# Altium schematic sheet MCU.SchDoc(MCU)
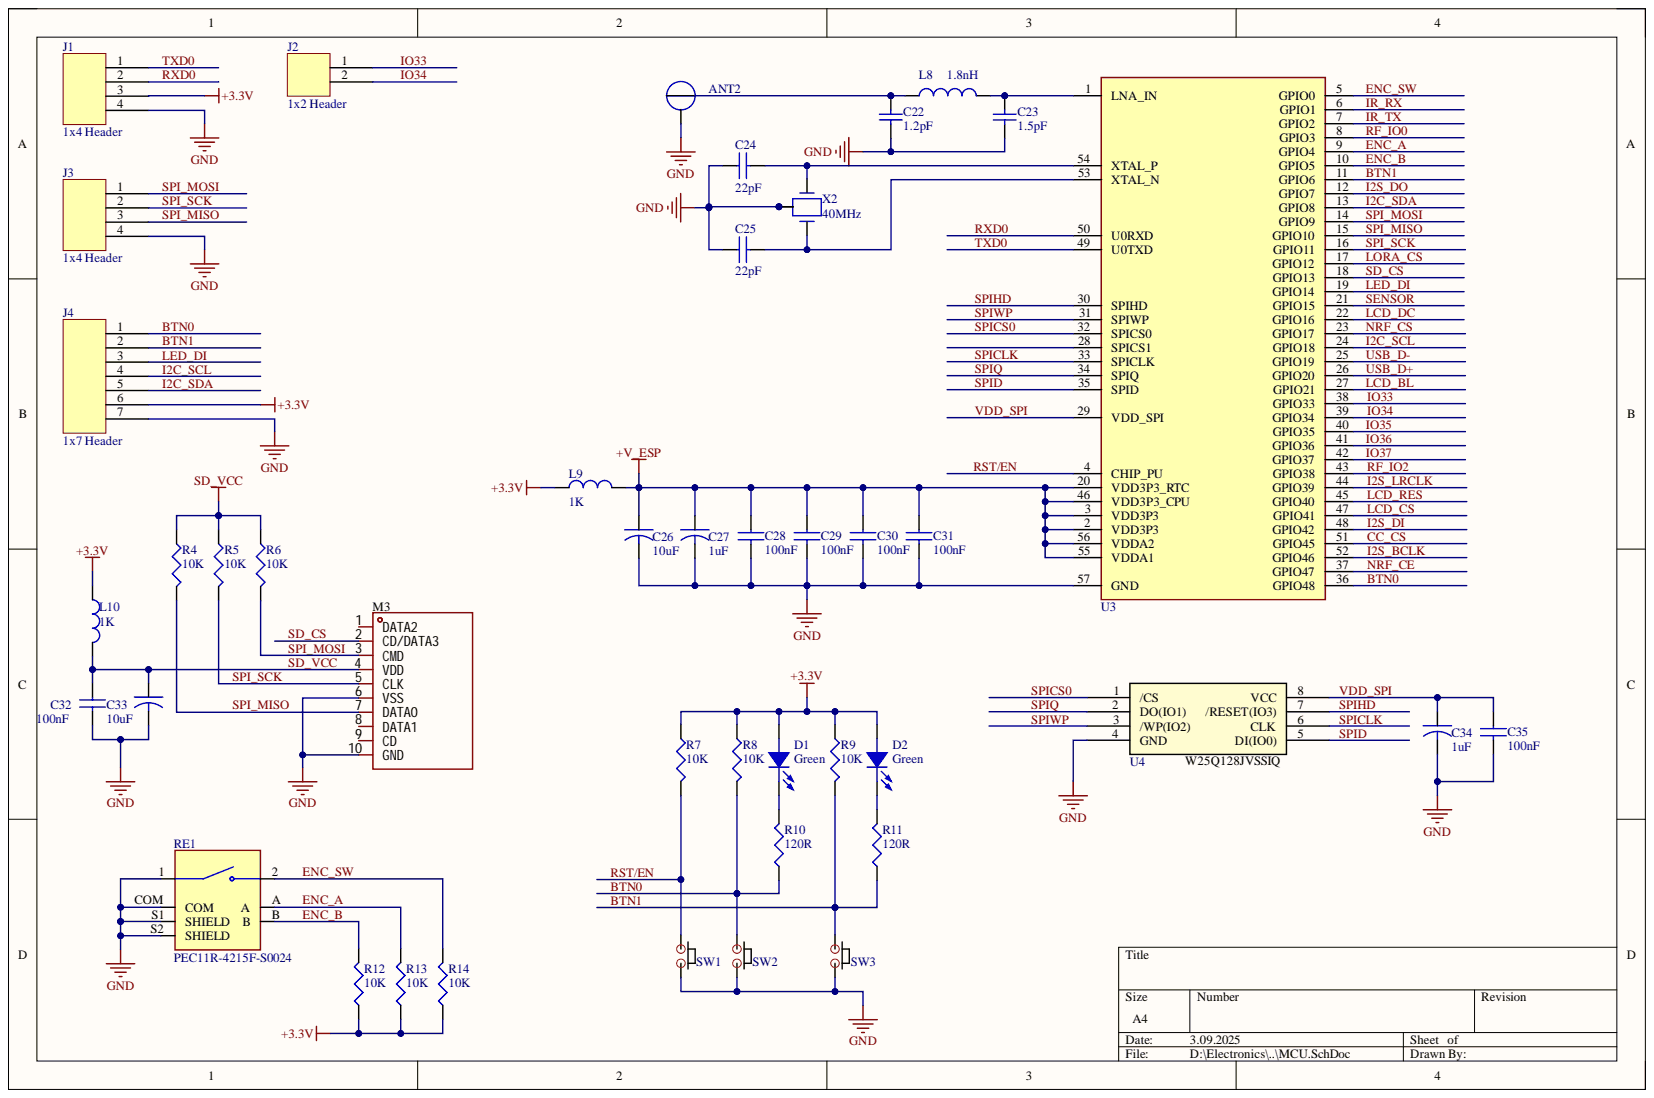
[diagram p0: MCU.SchDoc]

## Parts
ANT2  2.4HGz-PCB-ANT  [2.4HGZ-PCB-ANT]  (value not drawn)
C22  Capacitance: 1.2pF  [0603 SMD Ceramic Capacitor]  (hidden comment: CAP CER 1.2PF 25V C0G/NP0 0402)
C23  Capacitance: 1.5pF  [0603 SMD Ceramic Capacitor]  (hidden comment: CAP CER 1.5PF 25V C0G/NP0 0402)
C24  value 22pF  [0805 SMD Ceramic Capacitor]  (hidden comment: C0805C220)
C25  value 22pF  [0805 SMD Ceramic Capacitor]  (hidden comment: C0805C220)
C26  value 10uF  [A Case]  (hidden comment: T491A106)
C27  value 1uF  [A Case]  (hidden comment: T491A105)
C28  value 100nF  [0805 SMD Ceramic Capacitor]  (hidden comment: C0805C104)
C29  value 100nF  [0805 SMD Ceramic Capacitor]  (hidden comment: C0805C104)
C30  value 100nF  [0805 SMD Ceramic Capacitor]  (hidden comment: C0805C104)
C31  value 100nF  [0805 SMD Ceramic Capacitor]  (hidden comment: C0805C104)
C32  value 100nF  [0805 SMD Ceramic Capacitor]  (hidden comment: C0805C104)
C33  value 10uF  [A Case]  (hidden comment: T491A106)
C34  value 1uF  [A Case]  (hidden comment: T491A105)
C35  value 100nF  [0805 SMD Ceramic Capacitor]  (hidden comment: C0805C104)
D1  value Green  [0805 Green LED]  (hidden comment: 0805 Green LED)
D2  value Green  [0805 Green LED]  (hidden comment: 0805 Green LED)
J1  value 1x4 Header  [1x4 4 Pin Header]  (hidden comment: 1x4 4 Pin Header)
J2  value 1x2 Header  [1x2 2 Pin Header]  (hidden comment: 1x2 2 Pin Header)
J3  value 1x4 Header  [1x4 4 Pin Header]  (hidden comment: 1x4 4 Pin Header)
J4  value 1x7 Header  [1x7 7 Pin Header]  (hidden comment: 1x7 7 Pin Header)
L8  1.8nH  [0603 Inductor]
L9  value 1K  [0805 Inductor]  (hidden comment: 0805Ferrite)
L10  value 1K  [0805 Inductor]  (hidden comment: 0805Ferrite)
M3  XKTF-N02-G  [TF-SMD_XKTF-N02-G]  (value not drawn)
R4  value 10K  [0805 Resistor]  (hidden comment: 0805_10K)
R5  value 10K  [0805 Resistor]  (hidden comment: 0805_10K)
R6  value 10K  [0805 Resistor]  (hidden comment: 0805_10K)
R7  value 10K  [0805 Resistor]  (hidden comment: 0805_10K)
R8  value 10K  [0805 Resistor]  (hidden comment: 0805_10K)
R9  value 10K  [0805 Resistor]  (hidden comment: 0805_10K)
R10  value 120R  [0805 Resistor]  (hidden comment: 0805_120R)
R11  value 120R  [0805 Resistor]  (hidden comment: 0805_120R)
R12  value 10K  [0805 Resistor]  (hidden comment: 0805_10K)
R13  value 10K  [0805 Resistor]  (hidden comment: 0805_10K)
R14  value 10K  [0805 Resistor]  (hidden comment: 0805_10K)
RE1  PEC11R-4215F-S0024  [XDCR_PEC11R-4215F-S0024]
SW1  2.5mm Tactile Push Button  [2.5mm Tactile Push Button]  (value not drawn)
SW2  2.5mm Tactile Push Button  [2.5mm Tactile Push Button]  (value not drawn)
SW3  2.5mm Tactile Push Button  [2.5mm Tactile Push Button]  (value not drawn)
U3  ESP32-S3R8  [QFN40P700X700X90-57N]  (value not drawn)
U4  value W25Q128JVSSIQ  Manufacturer Code: W25Q128JVSSIQ  [SOIC-8_5P28X5P28_WIN]  (hidden comment: W25Q64JVSSIQ)
X2  value 40MHz  [TSX-3225]  (hidden comment: SMD XTAL 40MHz)

## Nets
+3.3V: D1.1 D2.1 J1.3 J4.6 L9.1 L10.1 R7.1 R8.1 R9.1 R12.1 R13.1 R14.1
+V_ESP: C26.1 C27.1 C28.1 C29.1 C30.1 C31.1 L9.2 U3.2(VDD3P3) U3.3(VDD3P3) U3.20(VDD3P3_RTC) U3.46(VDD3P3_CPU) U3.55(VDDA1) U3.56(VDDA2)
GND: ANT2.2 C22.2 C23.2 C24.1 C25.1 C26.2 C27.2 C28.2 C29.2 C30.2 C31.2 C32.2 C33.2 C34.2 C35.2 J1.4 J3.4 J4.7 M3.6(VSS) M3.10(GND) RE1.1 RE1.COM RE1.S1(SHIELD) RE1.S2(SHIELD) SW1.2 SW2.2 SW3.2 U3.57(GND) U4.4(GND) X2.2 X2.4
BTN0: J4.1 R8.2 R10.1 SW2.1 U3.36(GPIO48)
BTN1: J4.2 R9.2 R11.1 SW3.1 U3.11(GPIO6)
CC_CS: U3.51(GPIO45)
ENC_A: R13.2 RE1.A U3.9(GPIO4)
ENC_B: R12.2 RE1.B U3.10(GPIO5)
ENC_SW: R14.2 RE1.2 U3.5(GPIO0)
I2C_SCL: J4.4 U3.24(GPIO18)
I2C_SDA: J4.5 U3.13(GPIO8)
I2S_BCLK: U3.52(GPIO46)
I2S_DI: U3.48(GPIO42)
I2S_DO: U3.12(GPIO7)
I2S_LRCLK: U3.44(GPIO39)
IO33: J2.1 U3.38(GPIO33)
IO34: J2.2 U3.39(GPIO34)
IO35: U3.40(GPIO35)
IO36: U3.41(GPIO36)
IO37: U3.42(GPIO37)
IR_RX: U3.6(GPIO1)
IR_TX: U3.7(GPIO2)
LCD_BL: U3.27(GPIO21)
LCD_CS: U3.47(GPIO41)
LCD_DC: U3.22(GPIO16)
LCD_RES: U3.45(GPIO40)
LED_DI: J4.3 U3.19(GPIO14)
LORA_CS: U3.17(GPIO12)
NRF_CE: U3.37(GPIO47)
NRF_CS: U3.23(GPIO17)
RF_IO0: U3.8(GPIO3)
RF_IO2: U3.43(GPIO38)
RST/EN: R7.2 SW1.1 U3.4(CHIP_PU)
RXD0: J1.2 U3.50(U0RXD)
SD_CS: M3.2(CD/DATA3) U3.18(GPIO13)
SD_VCC: C32.1 C33.1 L10.2 M3.4(VDD) R4.1 R5.1 R6.1
SENSOR: U3.21(GPIO15)
SPI_MISO: J3.3 M3.7(DATA0) R4.2 U3.15(GPIO10)
SPI_MOSI: J3.1 M3.3(CMD) R6.2 U3.14(GPIO9)
SPI_SCK: J3.2 M3.5(CLK) R5.2 U3.16(GPIO11)
SPICLK: U3.33(SPICLK) U4.6(CLK)
SPICS0: U3.32(SPICS0) U4.1(/CS)
SPID: U3.35(SPID) U4.5(DI(IO0))
SPIHD: U3.30(SPIHD) U4.7(/RESET(IO3))
SPIQ: U3.34(SPIQ) U4.2(DO(IO1))
SPIWP: U3.31(SPIWP) U4.3(/WP(IO2))
TXD0: J1.1 U3.49(U0TXD)
USB_D+: U3.26(GPIO20)
USB_D-: U3.25(GPIO19)
VDD_SPI: C34.1 C35.1 U3.29(VDD_SPI) U4.8(VCC)
NetANT2_1: ANT2.1 C22.1 L8.1
NetC23_1: C23.1 L8.2 U3.1(LNA_IN)
NetC24_2: C24.2 U3.54(XTAL_P) X2.1
NetC25_2: C25.2 U3.53(XTAL_N) X2.3
NetD1_2: D1.2 R10.2
NetD2_2: D2.2 R11.2

Not connected: M3 1(DATA2) 8(DATA1) 9(CD); U3 28(SPICS1)

## Library data of the parts
ANT2 = 2.4HGz-PCB-ANT: Value: 2.4GHz PCB Antenna; Component Kind: Standard; Library Name: Antenna.SchLib
C22 = LMF105B7104KVHF: Manufacturer: KEMET; Manufacturer Part Number: C0402C129D3GACTU; Component Kind: Standard; Description: CAP CER 1.2PF 25V C0G/NP0 0402; Library Name: Passives.SchLib; Part Name: C0402C129D3GACTU
C23 = LMF105B7104KVHF: Manufacturer: Würth Elektronik; Manufacturer Part Number: 885012005035; Component Kind: Standard; Description: CAP CER 1.5PF 25V C0G/NP0 0402; Library Name: Passives.SchLib; Part Name: 885012005035
C24 C25 = C0805C220: Manufacturer: MULTICOMP; Manufacturer Code: MC0805N220J500CT; Tolerace: ± 5%; Voltage: 50V; Component Kind: Standard; Farnell Code: 1759195; Library Name: Capacitors.SchLib; Package: 0805; Unit Price: 0,0291 €
C26 C33 = T491A106: Manufacturer: KEMET; Manufacturer Code: T491A106K006AT; Voltage: 6V3; Component Kind: Standard; Farnell Code: 1457412; Library Name: Capacitors.SchLib; Unit Price: 0,143 €
C27 C34 = T491A105: Manufacturer: KEMET; Manufacturer Code: T491A105M016AT; Capacitance Tolerance: ±20%; Voltage: 16V; Component Kind: Standard; Farnell Code: 1163340; Library Name: Capacitors.SchLib; MOQ: 1; Unit Price: 0,143 €
C28-C32 C35 = C0805C104: Manufacturer: KEMET; Manufacturer Code: C0805C104J5RACTU; Voltage: 50V; Component Kind: Standard; Farnell Code: 1414663; Library Name: Capacitors.SchLib; Package: 0805; Unit Price: 0,06 €
D1 D2 = 0805 Green LED: Manufacturer: AVAGO Technologies; Manufacturer Code: HSMG-C170; Current: 20mA; Voltage: 2.2V; Component Kind: Standard; Farnell Code: 5790852; Library Name: LED.SchLib; Unit Price: 0,134 €
L8 = Inductor: FIXED IND 1.8NH 900MA 0.08OHM SM; Manufacturer: Murata Electronics; Manufacturer Part Number: LQG15HN1N8S02D; Component Kind: Standard; Library Name: ALT_NA_LIB.SchLib; VALUE: 1.8nH
L9 L10 = 0805Ferrite: Manufacturer: TDK; Manufacturer Code: MMZ2012R102A; Current: 500mA; Component Kind: Standard; Farnell Code: 1669719; Library Name: FerriteBead.SchLib; Unit Price: 0,059 €
M3 = XKTF-N02-G: Manufacturer: XKB Connection; Value: uSD Card; Component Kind: Standard; Library Name: XKTF-N02-G.IntLib; Manufacturer Part: XKTF-N02-G; Unit Price: 0.1025 $
R4-R9 R12-R14 = 0805_10K: Manufacturer: MULTICOMP; Manufacturer Code: MC 0.1W 0805 1% 10K; Tolerance: ±1%; Component Kind: Standard; Farnell Code: 9332391; Library Name: Resistors.SchLib; Unit Price: 0,03 €
R10 R11 = 0805_120R: Manufacturer: MULTICOMP; Manufacturer Code: MC 0.1W 0805 1% 120R; Tolerance: ±1%; Component Kind: Standard; Farnell Code: 9332480; Library Name: Resistors.SchLib; Unit Price: 0,03 €
RE1 = PEC11R-4215F-S0024: Incremental Encoder, 12 Mm, Contact Rating 10 Ma - 5 Vdc; Availability: Unavailable; Component Kind: Standard; Library Name: PEC11R-4215F-S0024.IntLib; MP: PEC11R-4215F-S0024; Package: None; Price: None
U3 = ESP32-S3R8: IC RF TxRx + MCU Bluetooth, WiFi 802.11b/g/n, Bluetooth v5.0 2.412GHz ~ 2.484GHz 56-VFQFN Exposed Pad; Manufacturer: Espressif Systems; Component Kind: Standard; Library Name: ESP32-S3R8.SchLib; Manufacturer Code: ESP32-S3R8; Package: VQFN-56; Price Unit: $; Unit Price: 2.28; Value: ESP32-S3R8
U4 = W25Q64JVSSIQ: FLASH - NOR Memory IC 64Mbit SPI - Quad I/O 133 MHz 8-SOIC; Manufacturer: Winbond; Component Kind: Standard; Digikey Code: W25Q128JVSSIQ-ND; Library Name: W25Q64JVSSIQ.IntLib; Package: SOIC-8; Unit Price: 1.09 $
X2 = SMD XTAL 40MHz: Manufacturer: EPSON; Manufacturer Code: TSX-3225 40.0000MF15Y-K0; Load Capacitance: 15pF; Tolerance: ± 10ppm; Component Kind: Standard; Library Name: Crystal.SchLib; Price Unit: $; Unit Price: 0.39
J1 J3 = 1x4 4 Pin Header: Component Kind: Standard; Library Name: Header.SchLib
J2 = 1x2 2 Pin Header: Component Kind: Standard; Library Name: Header.SchLib
J4 = 1x7 7 Pin Header: Component Kind: Standard; Library Name: Header.SchLib
SW1-SW3 = 2.5mm Tactile Push Button: Component Kind: Standard; Library Name: ButtonSwitch.SchLib; Value: 2.5mm Tactile Push Button
